# Altium schematic sheet 3. I2C&UART&RS485.SchDoc("3. I2C&UART&RS485")
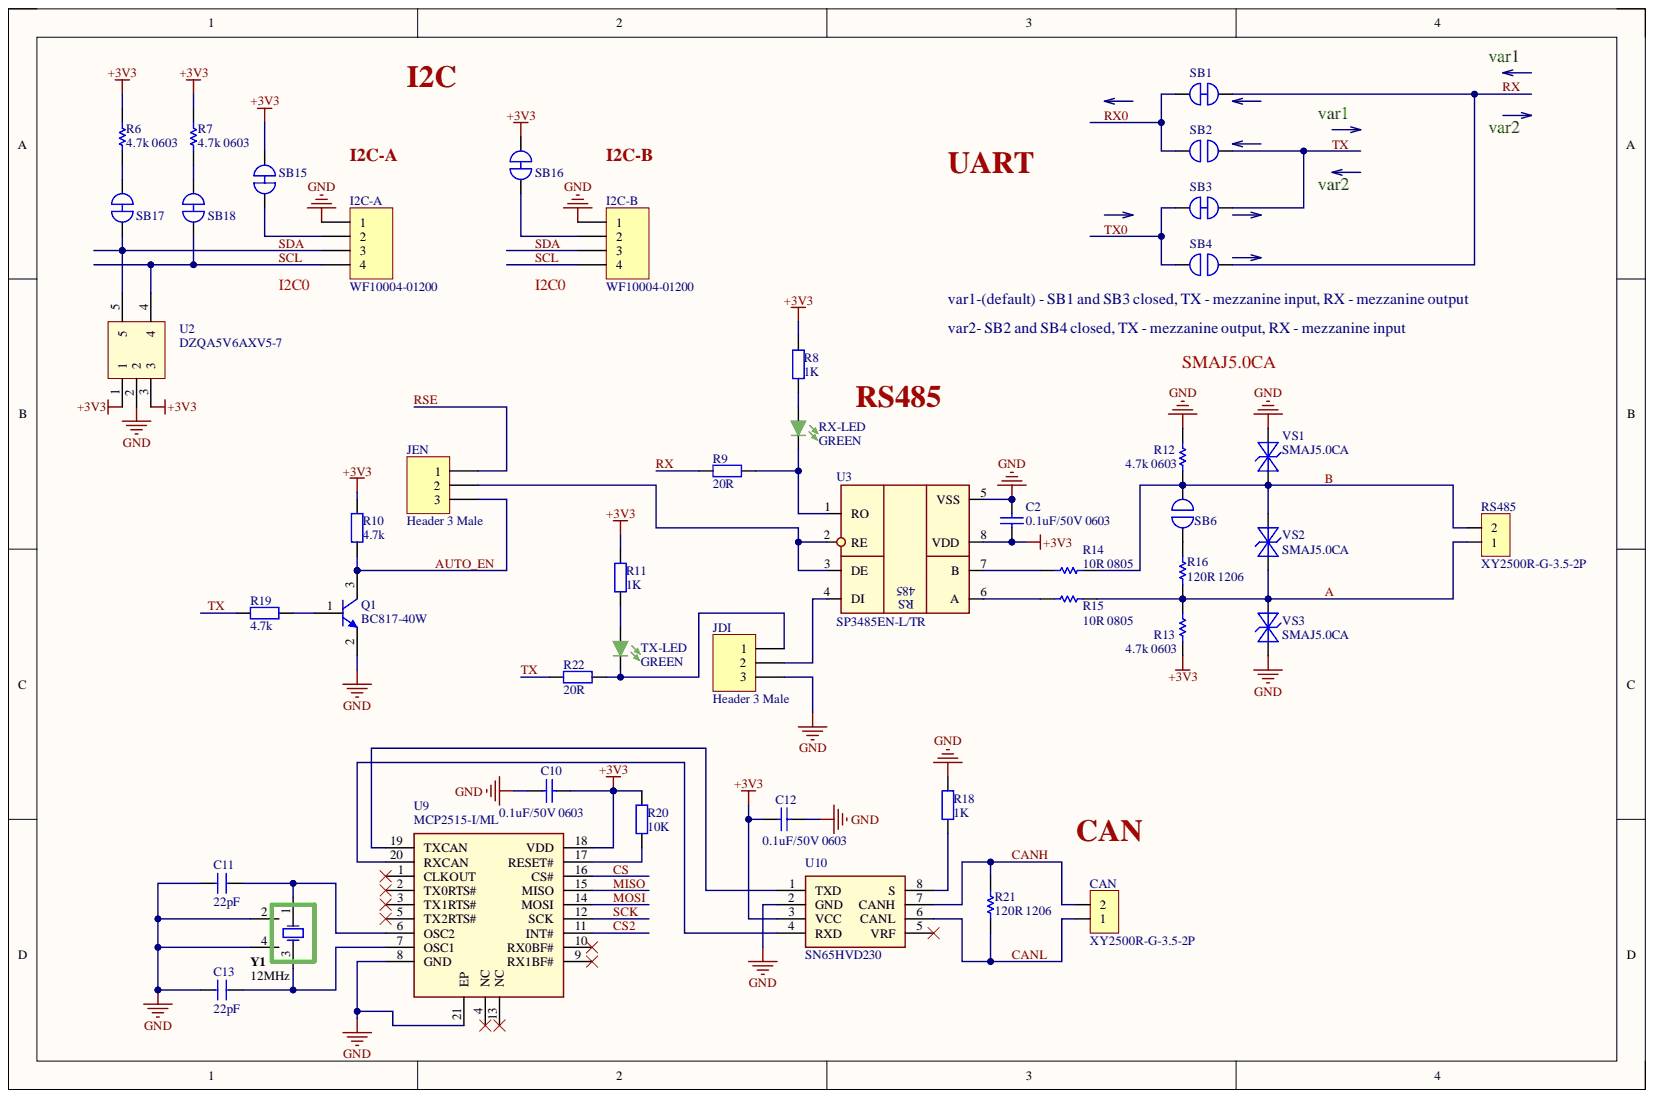
[diagram p0: 3. I2C&UART&RS485.SchDoc]

## Parts
C2  0.1uF/50V 0603  [CAPC1608X100N]
C10  0.1uF/50V 0603  [CAPC1608X100N]
C11  22pF  [CN-SMD-0402]
C12  0.1uF/50V 0603  [CAPC1608X100N]
C13  22pF  [CN-SMD-0402]
CAN  XY2500R-G-3.5-2P  [CON_1X02_3.5MM_MALE_RA]
I2C-A  WF10004-01200  [SM04B-SRSS-TB(LF)(SN)]
I2C-B  WF10004-01200  [SM04B-SRSS-TB(LF)(SN)]
JDI  Header 3 Male  [CON_1X03_2.54MM_MALE_STRAIGHT]
JEN  Header 3 Male  [CON_1X03_2.54MM_MALE_STRAIGHT]
Q1  BC817-40W  [SOT-323]
R6  4.7k 0603  [RESC1608X50N]
R7  4.7k 0603  [RESC1608X50N]
R8  1K  [RESC-0603 (1608) - HD]
R9  20R  [RESC-0603 (1608) - HD]
R10  4.7k  [RESC-0603 (1608) - HD]
R11  1K  [RESC-0603 (1608) - HD]
R12  4.7k 0603  [RESC1608X50N]
R13  4.7k 0603  [RESC1608X50N]
R14  10R 0805  [RES 0805_2012]
R15  10R 0805  [RES 0805_2012]
R16  120R 1206  [RESC3216X70N]
R18  1K  [RESC-0603 (1608) - HD]
R19  4.7k  [RESC-0603 (1608) - HD]
R20  10K  [RESC-0603 (1608) - HD]
R21  120R 1206  [RESC3216X70N]
R22  20R  [RESC-0603 (1608) - HD]
RS485  XY2500R-G-3.5-2P  [CON_1X02_3.5MM_MALE_RA]
RX-LED  GREEN  [LED0603_GREEN]
SB1  SOLDER_JUMPER_NC  [SOLDER_JUMPER_NC]  (value not drawn)  (no BOM)
SB2  SOLDER_JUMPER_NO  [SOLDER_JUMPER_NO_2]  (value not drawn)  (no BOM)
SB3  SOLDER_JUMPER_NC  [SOLDER_JUMPER_NC]  (value not drawn)  (no BOM)
SB4  SOLDER_JUMPER_NO  [SOLDER_JUMPER_NO_2]  (value not drawn)  (no BOM)
SB6  SOLDER_JUMPER_NO  [SOLDER_JUMPER_NO_2]  (value not drawn)  (no BOM)
SB15  SOLDER_JUMPER_NC  [SOLDER_JUMPER_NC]  (value not drawn)  (no BOM)
SB16  SOLDER_JUMPER_NC  [SOLDER_JUMPER_NC]  (value not drawn)  (no BOM)
SB17  SOLDER_JUMPER_NC  [SOLDER_JUMPER_NC]  (value not drawn)  (no BOM)
SB18  SOLDER_JUMPER_NC  [SOLDER_JUMPER_NC]  (value not drawn)  (no BOM)
TX-LED  GREEN  [LED0603_GREEN]
U2  DZQA5V6AXV5-7  [SOT50P160X60-5N]
U3  SP3485EN-L/TR  [SO8]
U9  MCP2515-I/ML  [QFN-20_4X4MM]
U10  SN65HVD230  [SOIC-8]
VS1  SMAJ5.0CA  [SMAJ_BI]
VS2  SMAJ5.0CA  [SMAJ_BI]
VS3  SMAJ5.0CA  [SMAJ_BI]
Y1  12MHz  [XTAL_3.2_2.5]

## Nets
+3V3: C2.1 C10.2 C12.1 R6.1 R7.1 R8.2 R10.2 R11.2 R13.1 R20.2 SB15.1 SB16.1 U2.1 U2.3 U3.8 U9.18 U10.3
GND: C2.2 C10.1 C11.1 C12.2 C13.1 I2C-A.1 I2C-B.1 JDI.3 Q1.2 R12.1 R18.2 U2.2 U3.5 U9.8 U9.21 U10.2 VS1.2 VS3.1 Y1.2 Y1.4
A: R13.2 R15.2 R16.1 RS485.1 VS2.1 VS3.2
AUTO_EN: JEN.3 Q1.3 R10.1
B: R12.2 R14.2 RS485.2 SB6.2 VS1.1 VS2.2
CANH: CAN.2 R21.2 U10.7
CANL: CAN.1 R21.1 U10.6
CS: U9.16
CS2: U9.11
MISO: U9.15
MOSI: U9.14
RSE: JEN.1
RX: R9.1 SB1.2 SB4.2
RX0: SB1.1 SB2.1
SCK: U9.12
SCL: I2C-A.4 I2C-B.4 SB18.2 U2.4
SDA: I2C-A.3 I2C-B.3 SB17.2 U2.5
TX: R19.2 R22.1 SB2.2 SB3.2
TX0: SB3.1 SB4.1
NetC11_2: C11.2 U9.6 Y1.1
NetC13_2: C13.2 U9.7 Y1.3
NetI2C-A_2: I2C-A.2 SB15.2
NetI2C-B_2: I2C-B.2 SB16.2
NetJDI_1: JDI.1 R22.2 TX-LED.K
NetJDI_2: JDI.2 U3.4
NetJEN_2: JEN.2 U3.2 U3.3
NetQ1_1: Q1.1 R19.1
NetR6_2: R6.2 SB17.1
NetR7_2: R7.2 SB18.1
NetR8_1: R8.1 RX-LED.A
NetR9_2: R9.2 RX-LED.K U3.1
NetR11_1: R11.1 TX-LED.A
NetR14_1: R14.1 U3.7
NetR15_1: R15.1 U3.6
NetR16_2: R16.2 SB6.1
NetR18_1: R18.1 U10.8
NetR20_1: R20.1 U9.17
NetU9_19: U9.19 U10.1
NetU9_20: U9.20 U10.4

Not connected: U9 1 2 3 4 5 9 10 13; U10 5

## Library data of the parts
C2 C10 C12 = Cap: 50V 100nF X7R ±10% 0603 Multilayer Ceramic Capacitors MLCC - SMD/SMT ROHS; Manufacturer: Walsin Tech Corp; Manufacturer Part Number: 0603B104K500CT; Component Kind: Standard; Library Name: Miscellaneous Devices.IntLib; Signal Integrity: Cap; Simulation: CAP; Supplier 1: LCSC; Supplier Part Number 1: C314282; Value: 0.1uF/50V 0603
C11 C13 = C0402_22P: Component Kind: Standard; Description: C0402_22P; Library Name: Bokra.SCHLIB
CAN RS485 = CON_1X02_3.5MM_MALE_RA: 300V 8A 2 Bend 1 3.5mm 1x2P Green Board Edge/Receptacle-Close Push-Pull,P=3.5mm Pluggable System Terminal Block ROHS; Manufacturer: Ningbo Xinlaiya Elec.; Component Kind: Standard; Library Name: Schematic_Lib.SchLib; Manufacturer Part Number: XY2500R-G-3.5-2P; Supplier 1: LCSC; Supplier Part Number 1: C880572; Value: XY2500R-G-3.5-2P
I2C-A = Header 4: Header, 4-Pin; Manufacturer: SHENZHEN ATOM TECH; Component Kind: Standard; Library Name: Miscellaneous Connectors.IntLib; Manufacturer Part Number: WF10004-01200; Signal Integrity: Connector; Supplier 1: LCSC; Supplier Part Number 1: C479990
I2C-B = Header 4: 1x4P 1 1mm Male pin 4 -25℃~+85℃ 500mA Surface Mount SMD,P=1mm Wire To Board / Wire To Wire Connector ROHS; Manufacturer: SHENZHEN ATOM TECH; Component Kind: Standard; Library Name: Miscellaneous Connectors.IntLib; Manufacturer Part Number: WF10004-01200; Signal Integrity: Connector; Supplier 1: LCSC; Supplier Part Number 1: C479990
JDI JEN = Header 3: Gold 250V 3A Straight Square Pins 2.54mm 3P 260℃ 6mm -40℃~+105℃ 3mm 2.54mm Brass Black Plugin 2.54mm 1x3P Plugin,P=2.54mm Pin Headers ROHS; Manufacturer: XFCN; Manufacturer Part Number: PZ254V-11-03P-YL; Component Kind: Standard; Library Name: Miscellaneous Connectors.IntLib; Supplier 1: LCSC; Supplier Part Number 1: C41425306
Q1 = BC817-40W: -65℃~+150℃ 100MHz 100nA 250@100mA,1V 290mW 45V 500mA 5V 700mV NPN SOT-323 Bipolar (BJT) ROHS; Manufacturer: Nexperia; Manufacturer Part Number: BC817-40W,115; Component Kind: Standard; Supplier Part Number 1: C75565; Library Name: Bokra.SCHLIB
R6 R7 R12 R13 = Res1: 100mW Thick Film Resistors ±100ppm/℃ ±1% 4.7kΩ 0603 Chip Resistor - Surface Mount ROHS; Manufacturer: UNI-ROYAL(Uniroyal Elec); Manufacturer Part Number: 0603WAF4701T5E; Component Kind: Standard; Library Name: Miscellaneous Devices.IntLib; Supplier 1: LCSC; Supplier Part Number 1: C23162; Value: 4.7k 0603
R8 R11 R18 = RC0603FR-071KL: RES SMD 1 KOHM 1% 1/10W 0603; Solder: SMT; Component Kind: Standard; MPN: RC0603FR-071KL; Supplier Part Number 1: C22548; Value: 1K; Library Name: Bokra.SCHLIB
R9 R22 = RC0603FR-0720RL: -55℃~+155℃ 100mW 20Ω 75V Thick Film Resistor ±1% ±100ppm/℃ 0603 Chip Resistor - Surface Mount ROHS; MFR: YAGEO; Solder: SMT; Category: RESISTOR; Component Kind: Standard; MPN: RC0603FR-0720RL; Supplier Part Number 1: C125758; Value: 20R; Library Name: Bokra.SCHLIB
R10 R19 = RC0603FR-074K7L: RES 4.7K OHM 1% 1/10W 0603; MFR: Yageo; Category: RESISTOR; Component Kind: Standard; MPN: RC0603FR-074K7L; Solder: RES-0603; Supplier Part Number 1: C99782; URL: https://www.digikey.com/en/products/detail/yageo/RC0603FR-074K7L/727212; Value: 4.7k; Library Name: Bokra.SCHLIB
R14 R15 = Res1: 125mW Thick Film Resistors ±1% ±200ppm/℃ 10Ω 0805 Chip Resistor - Surface Mount ROHS; Manufacturer: UNI-ROYAL(Uniroyal Elec); Manufacturer Part Number: 0805W8F100JT5E; Component Kind: Standard; Library Name: Miscellaneous Devices.IntLib; Supplier 1: LCSC; Supplier Part Number 1: C17415; Value: 10R 0805
R16 R21 = Res1: 100mW Thick Film Resistors ±100ppm/℃ ±1% 120Ω 1206 Chip Resistor - Surface Mount ROHS JLSC #C114928; Manufacturer: UNI-ROYAL(Uniroyal Elec); Manufacturer Part Number: 0603WAF1200T5E; Component Kind: Standard; Library Name: Miscellaneous Devices.IntLib; Supplier 1: LCSC; Supplier Part Number 1: C114928; Value: 120R 1206
R20 = RC0603FR-0710KL: RES 10K OHM 1% 1/10W 0603; MFR: YAGEO; Solder: SMT; Component Kind: Standard; MPN: RC0603FR-0710KL; Supplier Part Number 1: C98220; Value: 10K; Library Name: Bokra.SCHLIB
RX-LED TX-LED = LED0603_GREEN: LED GREEN CLEAR SMD; Manufacturer: XINGLIGHT; Manufacturer Part Number: XL-1608SYGC-06; Dominant Wavelength: 571nm; Forward Current: 30mA; Forward Voltage: 2V; Lens Color: Colorless; Lens Style: Rectangular,Colorless; Luminous Intensity: 35mcd; Max Power Dissipation: 75mW; Number of Elements: 1; Reverse Voltage: 5V; Test Current: 20mA; Viewing Angle: 130°; Wavelength: 574nm; Case Code (Imperial): 0603; Case Code (Metric): 1608; Case/Package: 0603; Category: LEDs; Color: Green; Component Kind: Standard; ComponentLink1Description: https://datasheet.ciiva.com/2109/ltst-c191kgkt-2109973.pdf?src-supplier=Digi-Key; ComponentLink1URL: https://datasheet.ciiva.com/2109/ltst-c191kgkt-2109973.pdf?src-supplier=Digi-Key; Depth: 0.8mm; Height: 0.55mm; Illumination Color: Green; Lead Free: Lead Free; Length: 1.6mm; Lens Transparency: Clear; Max Operating Temperature: 85°C; Min Operating Temperature: -55°C; Mount: Surface Mount; Number of LEDs: 1; Number of Pins: 2; Package Quantity: 1; Packaging: Tape and Reel; Power Dissipation: 75mW; Power Rating: 75mW; Pricing 1: 1=0.26000, 10=0.14100, 100=0.06560, 500=0.06060, 1000=0.04747, 2000=0.04646 (USD); Radiation Hardening: No; RoHS: Yes; RoHS Compliant: Yes; Stock 1: 910352; Supplier 1: LCSC; Supplier Part Number 1: C965805; Width: 0.8mm; Library Name: Bokra.SCHLIB
SB1 SB3 SB15-SB18 = SOLDER_JUMPER_NC: Solder Jumper; Library Name: Schematic_Lib.SchLib
SB2 SB4 SB6 = SOLDER_JUMPER_NO: Solder Jumper; Library Name: Schematic_Lib.SchLib
U2 = NZQA5V6XV5T1G: 5.3V 13V 4 3V SOT-553 ESD Protection Devices ROHS; Manufacturer: Diodes Incorporated; Component Kind: Standard; Manufacturer Part Number: DZQA5V6AXV5-7; Supplier 1: LCSC; Supplier Part Number 1: C1973056; Library Name: IoTbase B+ 3-01.SCHLIB
U3 = ST1480ABDR: transceiver 10Mbps 1/1 SOP-8 RS-485/RS-422 ICs ROHS; Manufacturer: MaxLinear; Component Kind: Standard; Library Name: BTGV6_0814.SchLib; Manufacturer Part Number: SP3485EN-L/TR; Supplier 1: LCSC; Supplier Part Number 1: C8963
U9 = MCP2515-I/ML: -40℃~+85℃ 1Mbps 1uA 2.7V~5.5V 5mA CAN Controller SPI QFN-20(4x4) CAN Controllers ROHS; Component Kind: Standard; Supplier Part Number 1: C637694; Library Name: Bokra.SCHLIB
U10 = SN65HVD230: -40℃~+85℃ 17mA 1Mbps 370uA 3V~3.6V CAN Transceiver SOIC-8 CAN Transceivers ROHS; Component Kind: Standard; Supplier Part Number 1: C12084; Library Name: Bokra.SCHLIB
VS1-VS3 = SMAJxxCA: 9.2V 6.4V 5V SMA(DO-214AC) TVS ROHS; Manufacturer: GOODWORK; Component Kind: Standard; Manufacturer Part Number: SMAJ5.0CA; PartNumber1: SMAJ5.0CA; Supplier 1: LCSC; Supplier Part Number 1: C908809; Value: SMAJ5.0CA; Library Name: IoTextra_Input_2-03.SCHLIB
Y1 = X322512MSB4SI: -40℃~+85℃ 12MHz 20pF Crystal Oscillator ±10ppm ±20ppm SMD3225-4P Crystals ROHS; Manufacturer: YXC Crystal Oscillators; Component Kind: Standard; Manufacturer Part Number: X322512MSB4SI; Supplier Part Number 1: C9002; Library Name: Bokra.SCHLIB
